# Altium schematic sheet I2C_mux.SchDoc(I2C_mux)
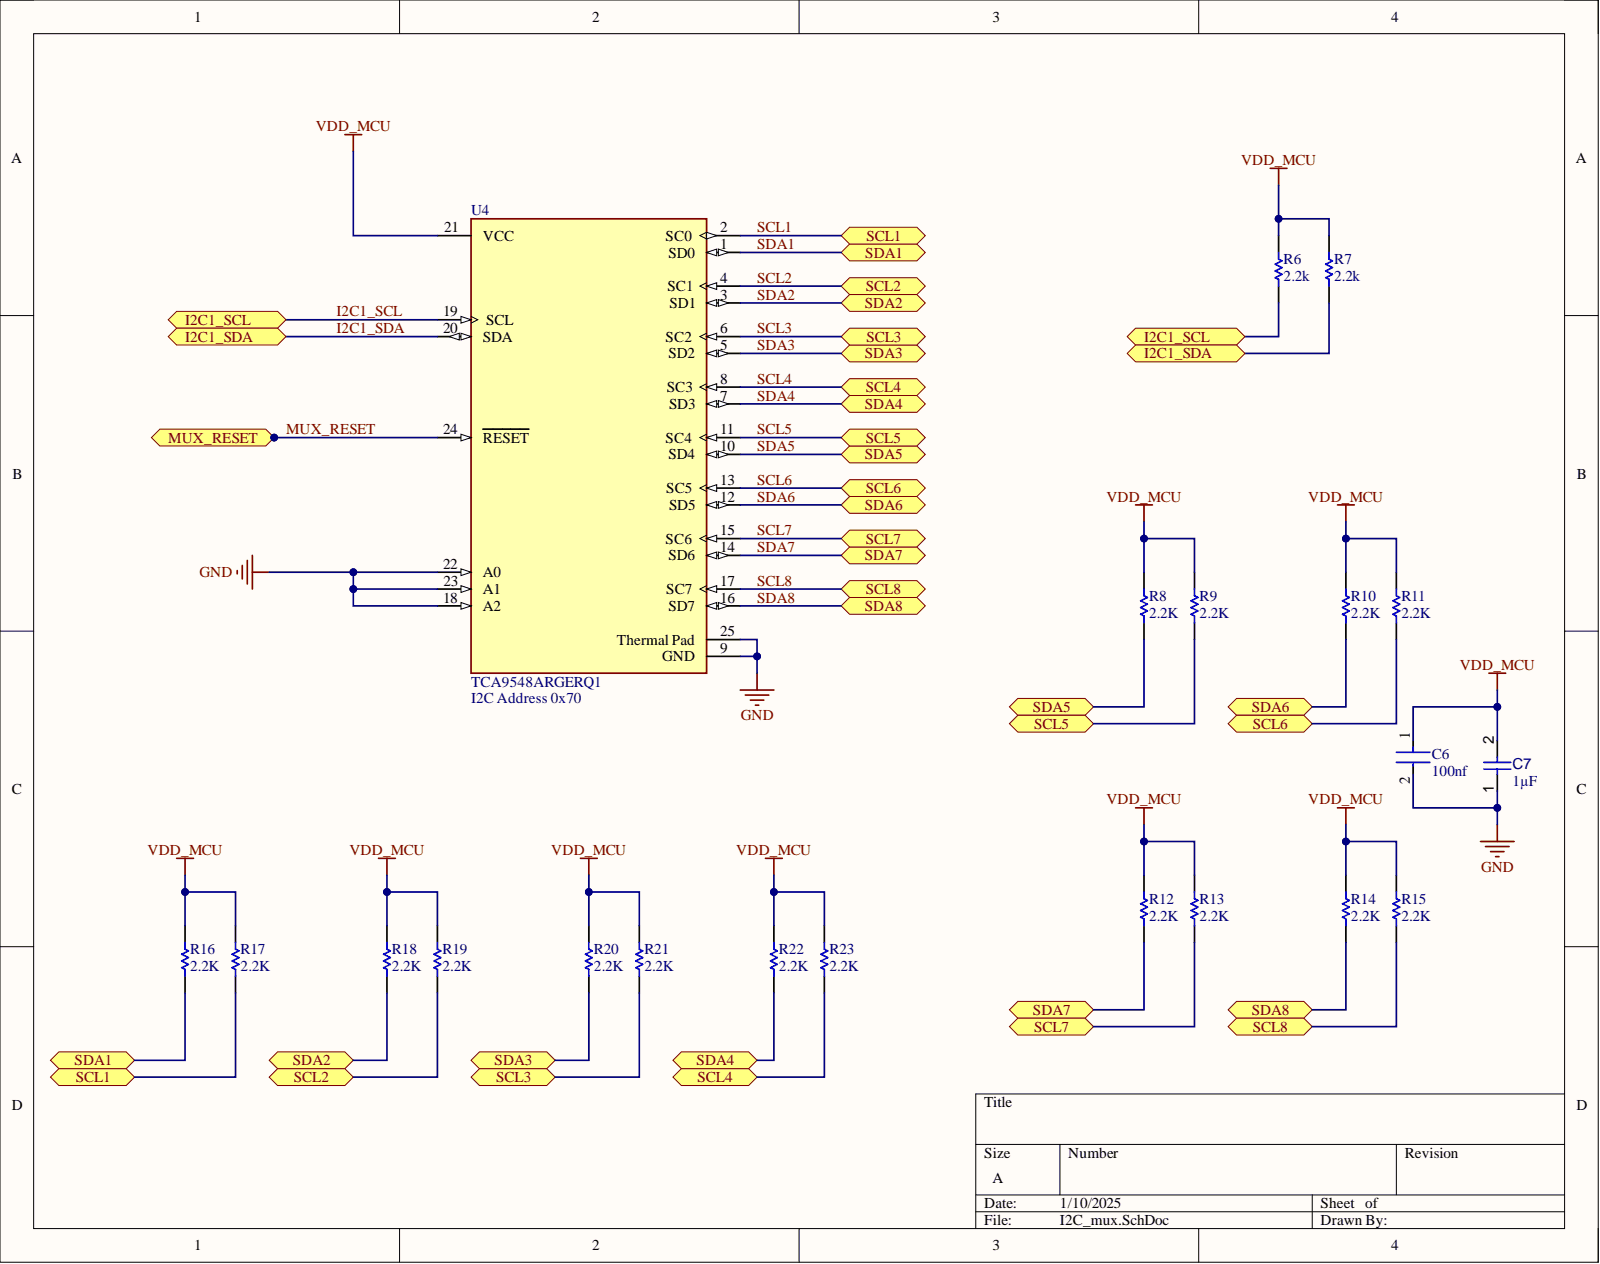
[diagram p0: I2C_mux.SchDoc]

## Parts
C6  100nf
C7  value 1µF  (hidden comment: Capacitor 1 uF +/- 10% 6.3 V 0402)
R6  2.2k
R7  2.2k
R8  2.2K
R9  2.2K
R10  2.2K
R11  2.2K
R12  2.2K
R13  2.2K
R14  2.2K
R15  2.2K
R16  2.2K
R17  2.2K
R18  2.2K
R19  2.2K
R20  2.2K
R21  2.2K
R22  2.2K
R23  2.2K
U4  TCA9548ARGERQ1

## Ports
I2C1_SCL, I2C1_SDA, MUX_RESET, SCL1, SCL2, SCL3, SCL4, SCL5, SCL6, SCL7, SCL8, SDA1, SDA2, SDA3, SDA4, SDA5, SDA6, SDA7, SDA8

## Nets
GND: C6.2 C7.1 U4.9(GND) U4.18(A2) U4.22(A0) U4.23(A1) U4.25(Thermal_Pad)
VDD_MCU: C6.1 C7.2 R6.2 R7.2 R8.2 R9.2 R10.2 R11.2 R12.2 R13.2 R14.2 R15.2 R16.2 R17.2 R18.2 R19.2 R20.2 R21.2 R22.2 R23.2 U4.21(VCC)
I2C1_SCL: R6.1 U4.19(SCL) ; port I2C1_SCL
I2C1_SDA: R7.1 U4.20(SDA) ; port I2C1_SDA
MUX_RESET: U4.24(RESET) ; port MUX_RESET
SCL1: R17.1 U4.2(SC0) ; port SCL1
SCL2: R19.1 U4.4(SC1) ; port SCL2
SCL3: R21.1 U4.6(SC2) ; port SCL3
SCL4: R23.1 U4.8(SC3) ; port SCL4
SCL5: R9.1 U4.11(SC4) ; port SCL5
SCL6: R11.1 U4.13(SC5) ; port SCL6
SCL7: R13.1 U4.15(SC6) ; port SCL7
SCL8: R15.1 U4.17(SC7) ; port SCL8
SDA1: R16.1 U4.1(SD0) ; port SDA1
SDA2: R18.1 U4.3(SD1) ; port SDA2
SDA3: R20.1 U4.5(SD2) ; port SDA3
SDA4: R22.1 U4.7(SD3) ; port SDA4
SDA5: R8.1 U4.10(SD4) ; port SDA5
SDA6: R10.1 U4.12(SD5) ; port SDA6
SDA7: R12.1 U4.14(SD6) ; port SDA7
SDA8: R14.1 U4.16(SD7) ; port SDA8

## Library data of the parts
C6 = CMP-13271-001069-1: Cap Ceramic 100nF 10V X7R ±10% SMD 0402 +125°C Paper T/R; Capacitance: 100nF; Case Code (Imperial): 0402; Case Code (Metric): 1005; Case/Package: 0402; Component Kind: Standard; Contact Plating: Tin; Depth: 0.5mm; Dielectric: X7R; Height: 0.5mm; Length: 1mm; Library Name: Altium Content Vault; Max Operating Temperature: 125°C; Min Operating Temperature: -55°C; Mount: Surface Mount; Package Quantity: 1; Packaging: Tape and Reel; Radiation Hardening: No; Thickness: 0.022inch; Tolerance: 10%; Voltage Rating: 10V; Width: 0.02inch
C7 = CMP-001-00008-6: Chip Capacitor, 1 uF, +/- 10%, 6.3 V, 0402 (1005 Metric); Capacitor Type: Ceramic; Voltage Rating: 6.3V; Case/Package: 0402; Component Kind: Standard; Max Operating Temperature: 85°C; Min Operating Temperature: -55°C; Mounting Technology: SM; Pins: 2; RoHS Compliant: True; Tolerance: 10%; Library Name: Openwater
R6 R7 = CMP-2002-07517-1: Manufacturer: Yageo / Phycomp; Part Number: RC0402FR-0722KL; Component Kind: Standard; Library Name: Altium Content Vault
R8-R23 = CMP-2002-07567-1: Manufacturer: Yageo / Phycomp; Part Number: RC0402FR-072K2L; Component Kind: Standard; Library Name: Altium Content Vault
U4 = CMP-04892-000080-1: IC BUS SWITCH 1 X 8:1 24VQFN; Component Kind: Standard; Library Name: Altium Content Vault
